# Altium schematic sheet EncoderI2Cv2_4Layer.SchDoc(EncoderI2Cv2_4Layer)
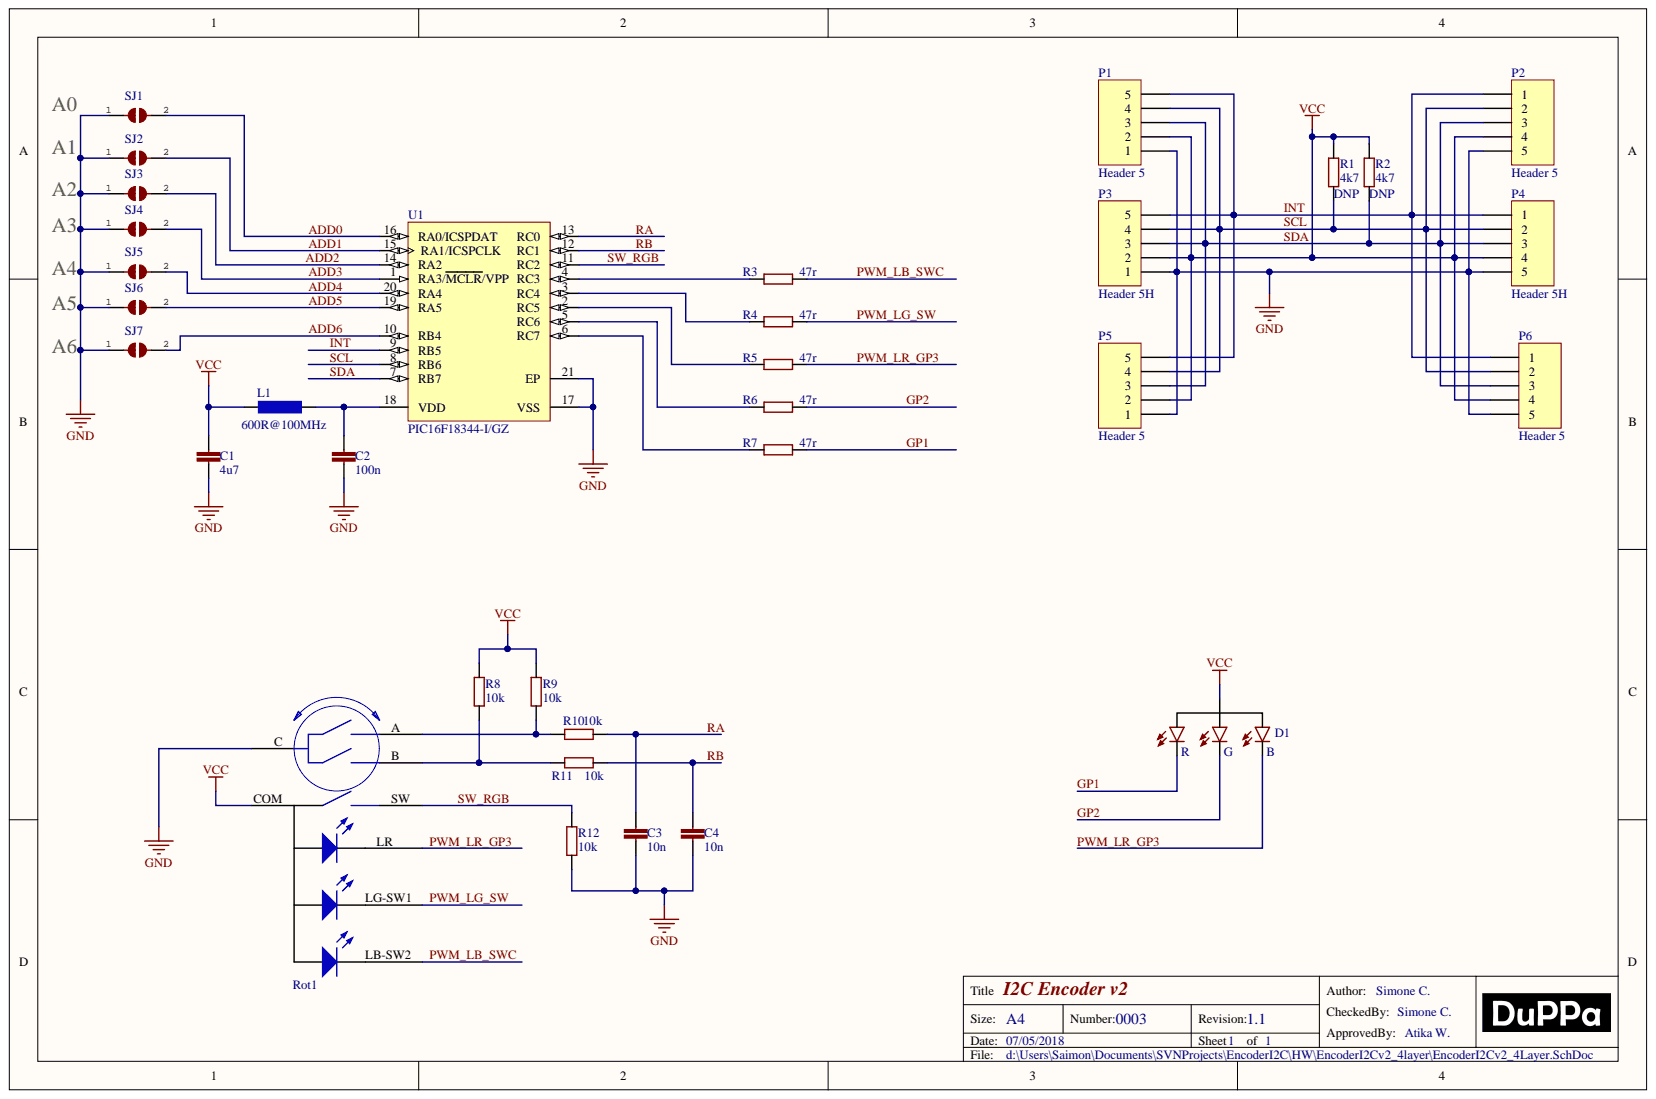
[diagram p0: EncoderI2Cv2_4Layer.SchDoc]

## Parts
C1  value 4u7  [C0805]  (hidden comment: C-EUC0805)
C2  value 100n  [C0402]  (hidden comment: C-EUC0402)
C3  value 10n  [C0402]  (hidden comment: C-EUC0402)
C4  value 10n  [C0402]  (hidden comment: C-EUC0402)
D1  LED-RGB-CA  [LED-RGB-CA-5mm]  (value not drawn)
L1  600R@100MHz  [L0402]
P1  Header 5  [HDR1X5]
P2  Header 5  [HDR1X5]
P3  Header 5H  [HDR1X5H]
P4  Header 5H  [HDR1X5H]
P5  Header 5  [HDR1X5]
P6  Header 5  [HDR1X5]
R1  value 4k7  Mount: DNP  [R0805]  (hidden comment: R-EU_R0805)
R2  value 4k7  Mount: DNP  [R0805]  (hidden comment: R-EU_R0805)
R3  value 47r  [R0402]  (hidden comment: R-EU_R0402)
R4  value 47r  [R0402]  (hidden comment: R-EU_R0402)
R5  value 47r  [R0402]  (hidden comment: R-EU_R0402)
R6  value 47r  [R0402]  (hidden comment: R-EU_R0402)
R7  value 47r  [R0402]  (hidden comment: R-EU_R0402)
R8  value 10k  [R0402]  (hidden comment: R-EU_R0402)
R9  value 10k  [R0402]  (hidden comment: R-EU_R0402)
R10  value 10k  [R0402]  (hidden comment: R-EU_R0402)
R11  value 10k  [R0402]  (hidden comment: R-EU_R0402)
R12  value 10k  [R0402]  (hidden comment: R-EU_R0402)
Rot1  RotaryEncoderPB_RGB_MIX  [RotaryEncoderRGBMix]  (value not drawn)
SJ1  SJ  [SJsmall]
SJ2  SJ  [SJsmall]
SJ3  SJ  [SJsmall]
SJ4  SJ  [SJsmall]
SJ5  SJ  [SJsmall]
SJ6  SJ  [SJsmall]
SJ7  SJ  [SJsmall]
U1  PIC16F18344-I/GZ  [MCHP-QFN-ML20_N]

## Nets
GND: C1.2 C2.2 C3.2 C4.2 P1.1 P2.5 P3.1 P4.5 P5.1 P6.5 R12.1 Rot1.C SJ1.1 SJ2.1 SJ3.1 SJ4.1 SJ5.1 SJ6.1 SJ7.1 U1.17(VSS) U1.21(EP)
VCC: C1.1 D1.A L1.1 P1.2 P2.4 P3.2 P4.4 P5.2 P6.4 R1.2 R2.2 R8.2 R9.2 Rot1.COM
ADD0: SJ1.2 U1.16(RA0/ICSPDAT)
ADD1: SJ2.2 U1.15(RA1/ICSPCLK)
ADD2: SJ3.2 U1.14(RA2)
ADD3: SJ4.2 U1.1(RA3/MCLR/VPP)
ADD4: SJ5.2 U1.20(RA4)
ADD5: SJ6.2 U1.19(RA5)
ADD6: SJ7.2 U1.10(RB4)
GP1: D1.CR R7.2
GP2: D1.CG R6.2
INT: P1.5 P2.1 P3.5 P4.1 P5.5 P6.1 U1.9(RB5)
PWM_LB_SWC: R3.1 Rot1.LB-SW2
PWM_LG_SW: R4.2 Rot1.LG-SW1
PWM_LR_GP3: D1.CB R5.2 Rot1.LR
RA: C3.1 R10.2 U1.13(RC0)
RB: C4.1 R11.2 U1.12(RC1)
SCL: P1.4 P2.2 P3.4 P4.2 P5.4 P6.2 R1.1 U1.8(RB6)
SDA: P1.3 P2.3 P3.3 P4.3 P5.3 P6.3 R2.1 U1.7(RB7)
SW_RGB: R12.2 Rot1.SW U1.11(RC2)
NetC2_1: C2.1 L1.2 U1.18(VDD)
NetR3_2: R3.2 U1.4(RC3)
NetR4_1: R4.1 U1.3(RC4)
NetR5_1: R5.1 U1.2(RC5)
NetR6_1: R6.1 U1.5(RC6)
NetR7_1: R7.1 U1.6(RC7)
NetR8_1: R8.1 R11.1 Rot1.B
NetR9_1: R9.1 R10.1 Rot1.A

## Library data of the parts
C1 = C-EUC0805: PartNumber: CC0805ZKY5V7BB475; MouserCode: 603-CC805ZKY5V7BB475; Library Name: rcl.IntLib; Component Kind: Standard
C2 = C-EUC0402: Capacitor; PartNumber: GRM155R61C104KA88D; MouserCode: 603-CC402KRX5R8BB104; Library Name: rcl.IntLib; Component Kind: Standard
C3 C4 = C-EUC0402: Capacitor; PartNumber: GRM155R71H103KA88D; MouserCode: 81-GRM155R71H103KA8D; Library Name: rcl.IntLib; Component Kind: Standard
L1 = FERRITE: Ferrite Bead; PartNumber: BK1005HS601-T; Value: 600R@100MHz; MouserCode: 963-BK1005HS601-T; Library Name: Miscellaneous Devices.IntLib; Component Kind: Standard
P1 P2 P5 P6 = Header 5: Header, 5-Pin; Signal Integrity: Connector; Library Name: Miscellaneous Connectors.IntLib; LatestRevisionNote: Re-released for DXP Platform.; LatestRevisionDate: 17-Jul-2002; Component Kind: Standard; Publisher: Altium Limited
P3 = Header 5H: Header, 5-Pin, Right Angle Male; Signal Integrity: Connector; PartNumber: 22-28-8050; MouserCode: 538-22-28-8050; Library Name: Miscellaneous Connectors.IntLib; Component Kind: Standard
P4 = Header 5H: Header, 5-Pin, Right Angle Female; PartNumber: BG302-05-A-L-G; Signal Integrity: Connector; Library Name: Miscellaneous Connectors.IntLib; FarnellCode: 2084275; Component Kind: Standard
R3-R7 = R-EU_R0402: Resistor; PartNumber: RC0402FR-0747RL; MouserCode: 603-RC0402FR-0747RL; Library Name: rcl.IntLib; Component Kind: Standard
R8-R12 = R-EU_R0402: Resistor; PartNumber: RC0402FR-0710KL; MouserCode: 603-RC0402FR-0710KL; Library Name: rcl.IntLib; Component Kind: Standard
Rot1 = RotaryEncoderPB_RGB_MIX: ROTARY ENCODER WITH PUSHBUTTON; Library Name: Miscellaneous Devices.IntLib; Component Kind: Standard
U1 = PIC16F15344-I/GZ: Flash, 8-Bit Microcontroller, 20 MHz, 17 I/O, -40 to 125 degC, 20-pin QFN (ML20), Tube; PartNumber: PIC16F18344-I/GZ; MouserCode: 579-PIC16F18344-I/GZ; Library Name: Microchip PIC16 Part 1.IntLib; Component Kind: Standard
D1 = LED-RGB-CA: Library Name: led.IntLib; Component Kind: Standard
R1 R2 = R-EU_R0805: Library Name: rcl.IntLib; Component Kind: Standard
SJ1-SJ7 = SJ: Library Name: jumper.SCHLIB; Component Kind: Standard
